# Altium schematic sheet Power.SchDoc
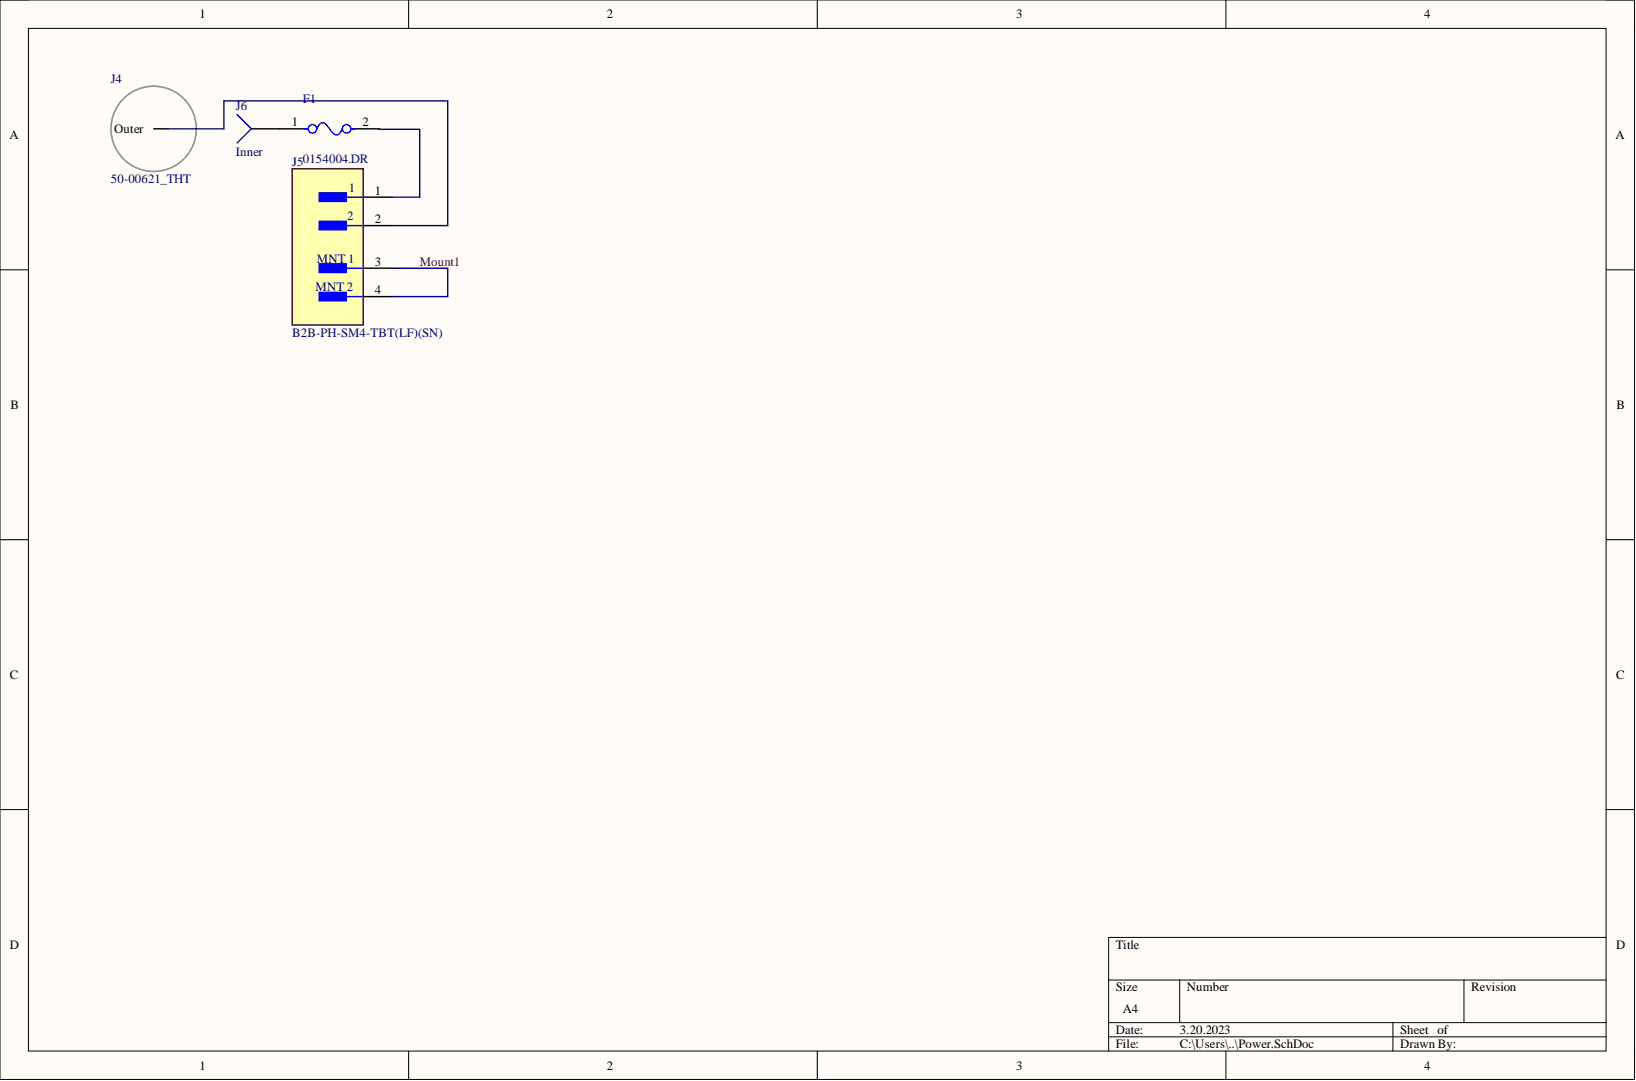
[diagram p0: Power.SchDoc]

## Parts
F1  0154004.DR  [FP-0154-MFG]
J4  50-00621_THT  [50-00621_THT]
J5  B2B-PH-SM4-TBT(LF)(SN)  [FP-B2B-PH-SM4-TBT_LF_SN-MFG]
J6  Inner  [PIN1]  (no BOM)

## Nets
Mount1: J5.3 J5.4
NetF1_1: F1.1 J6.1
NetF1_2: F1.2 J5.1
NetJ4_1: J4.1 J5.2

## Library data of the parts
F1 = CMP-08605-000003-1: FUSE BRD MNT 4A 125VAC/VDC 2SMD; Manufacturer: Littelfuse; Fuse Blow Speed: Fast; Max Fuse Ac Voltage: 125V; Automotive: No; Category: Fuse; Component Kind: Standard; ComponentLink2Description: Datasheet; ComponentLink2URL: https://www.littelfuse.com/~/media/electronics/datasheets/fuses/littelfuse_fuse_154_154t_154l_154tl_datasheet.pdf.pdf; Device Class L1: Circuit Protection; Device Class L2: Fuses; Device Class L3: unset; Digikey Description: FUSE BRD MNT 4A 125VAC/VDC 2SMD; Fuse Current: 4A; Height: 3.81mm; JLCPCBPartNum: C206914; Lead Free: Yes; Library Name: Altium Content Vault; Manufacturer Part Number: 0154004.DR; Max Fuse Dc Voltage: 125V; Mouser Description: Surface Mount Fuses Fuseblock w/ fuse 4A OMNI BLOK 154; Octopart: https://octopart.com/0154004.dr-littelfuse-565861; Package: 0154; RoHS: TRUE; Temperature: -55°C to +125°C; Temperature Range High: +125°C; Temperature Range Low: -55°C
J4 = 50-00621: Tensility Connector, dc plug, 4.0x1.7x21.3 mm, dual solder tabs, spring contacts, nickel plated, 105 °C; Component Kind: Standard; Library Name: MiniDin.IntLib
J5 = CMP-17439-000005-1: CONN HEADER SMD 2POS 2MM; Manufacturer: JST; Conn Gender: Male; Conn Orientation: Vertical; Current Rating: 2A; Number Of Contacts: 2; Voltage Rating: 100V; Automotive: No; Category: Conn; Component Kind: Standard; ComponentLink2Description: Datasheet; ComponentLink2URL: http://www.jst-mfg.com/product/pdf/eng/ePH.pdf; Contact Material: Tin,Copper; Device Class L1: Connectors; Device Class L2: Headers and Wire Housings; Device Class L3: unset; Digikey Description: CONN HEADER SMD 2POS 2MM; Height: 6.65mm; JLCPCBPartNum: C265003; Lead Free: Yes; Library Name: Altium Content Vault; Manufacturer Part Number: B2B-PH-SM4-TBT(LF)(SN); Number Of Rows: 1; Octopart: https://octopart.com/b2b-ph-sm4-tbt%28lf%29%28sn%29-jst-7877930; Package: 0; Pitch: 2mm; RoHS: TRUE; Temperature: -25°C to +85°C; Temperature Range High: +85°C; Temperature Range Low: -25°C
J6 = Socket: Description: Socket; LatestRevisionDate: 17-Jul-2002; LatestRevisionNote: Re-released for DXP Platform.; Library Name: Miscellaneous Connectors.IntLib; Signal Integrity: Connector; Publisher: Altium Limited
